# Altium schematic sheet [13] - FPGA POWER.SchDoc("[13] - FPGA POWER")
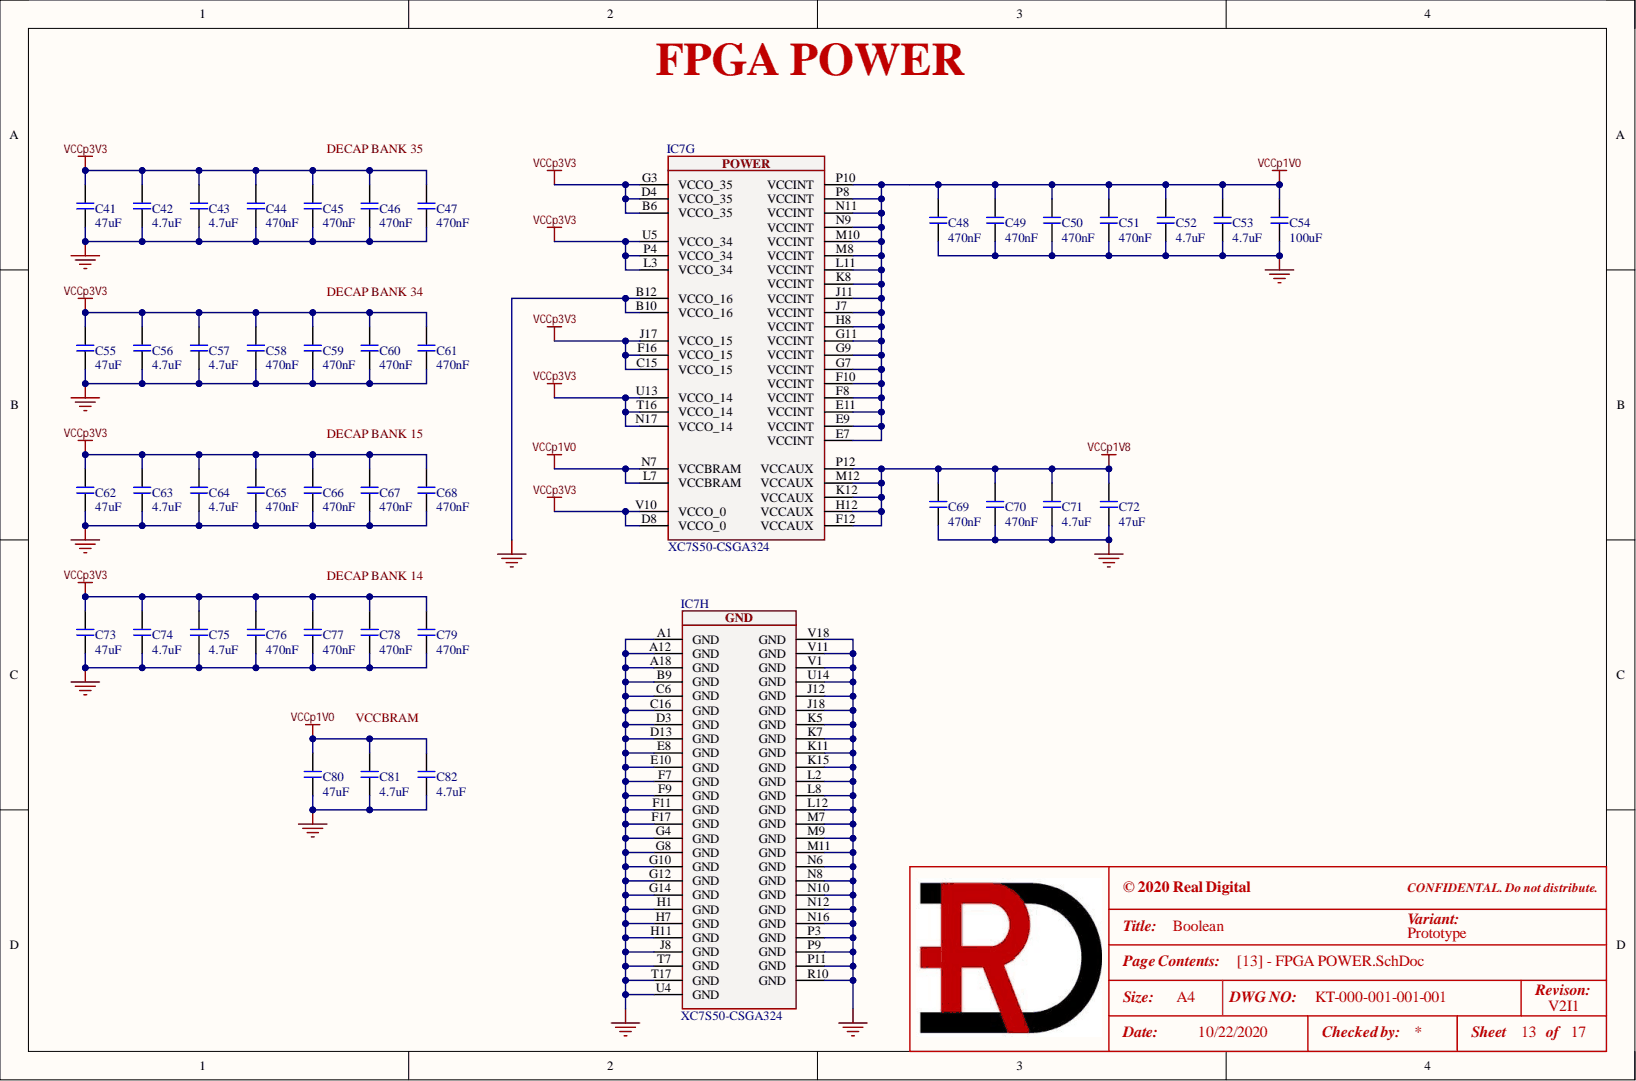
[diagram p0: [13] - FPGA POWER.SchDoc]

## Parts
C41  47uF  [C1210]
C42  4.7uF  [C0805]
C43  4.7uF  [C0805]
C44  470nF  [C0603]
C45  470nF  [C0603]
C46  470nF  [C0603]
C47  470nF  [C0603]
C48  470nF  [C0603]
C49  470nF  [C0603]
C50  470nF  [C0603]
C51  470nF  [C0603]
C52  4.7uF  [C0805]
C53  4.7uF  [C0805]
C54  100uF  [C1210]
C55  47uF  [C1210]
C56  4.7uF  [C0805]
C57  4.7uF  [C0805]
C58  470nF  [C0603]
C59  470nF  [C0603]
C60  470nF  [C0603]
C61  470nF  [C0603]
C62  47uF  [C1210]
C63  4.7uF  [C0805]
C64  4.7uF  [C0805]
C65  470nF  [C0603]
C66  470nF  [C0603]
C67  470nF  [C0603]
C68  470nF  [C0603]
C69  470nF  [C0603]
C70  470nF  [C0603]
C71  4.7uF  [C0805]
C72  47uF  [C1210]
C73  47uF  [C1210]
C74  4.7uF  [C0805]
C75  4.7uF  [C0805]
C76  470nF  [C0603]
C77  470nF  [C0603]
C78  470nF  [C0603]
C79  470nF  [C0603]
C80  47uF  [C1210]
C81  4.7uF  [C0805]
C82  4.7uF  [C0805]
IC7  XC7S50-CSGA324  [CSG324]  (parts G,H)

## Nets
GND: C41.1 C42.1 C43.1 C44.1 C45.1 C46.1 C47.1 C48.1 C49.1 C50.1 C51.1 C52.1 C53.1 C54.1 C55.1 C56.1 C57.1 C58.1 C59.1 C60.1 C61.1 C62.1 C63.1 C64.1 C65.1 C66.1 C67.1 C68.1 C69.1 C70.1 C71.1 C72.1 C73.1 C74.1 C75.1 C76.1 C77.1 C78.1 C79.1 C80.1 C81.1 C82.1 IC7.A1(GND) IC7.A12(GND) IC7.A18(GND) IC7.B9(GND) IC7.B10(VCCO_16) IC7.B12(VCCO_16) IC7.C6(GND) IC7.C16(GND) IC7.D3(GND) IC7.D13(GND) IC7.E8(GND) IC7.E10(GND) IC7.F7(GND) IC7.F9(GND) IC7.F11(GND) IC7.F17(GND) IC7.G4(GND) IC7.G8(GND) IC7.G10(GND) IC7.G12(GND) IC7.G14(GND) IC7.H1(GND) IC7.H7(GND) IC7.H11(GND) IC7.J8(GND) IC7.J12(GND) IC7.J18(GND) IC7.K5(GND) IC7.K7(GND) IC7.K11(GND) IC7.K15(GND) IC7.L2(GND) IC7.L8(GND) IC7.L12(GND) IC7.M7(GND) IC7.M9(GND) IC7.M11(GND) IC7.N6(GND) IC7.N8(GND) IC7.N10(GND) IC7.N12(GND) IC7.N16(GND) IC7.P3(GND) IC7.P9(GND) IC7.P11(GND) IC7.R10(GND) IC7.T7(GND) IC7.T17(GND) IC7.U4(GND) IC7.U14(GND) IC7.V1(GND) IC7.V11(GND) IC7.V18(GND)
VCCp1V0: C48.2 C49.2 C50.2 C51.2 C52.2 C53.2 C54.2 C80.2 C81.2 C82.2 IC7.E7(VCCINT) IC7.E9(VCCINT) IC7.E11(VCCINT) IC7.F8(VCCINT) IC7.F10(VCCINT) IC7.G7(VCCINT) IC7.G9(VCCINT) IC7.G11(VCCINT) IC7.H8(VCCINT) IC7.J7(VCCINT) IC7.J11(VCCINT) IC7.K8(VCCINT) IC7.L7(VCCBRAM) IC7.L11(VCCINT) IC7.M8(VCCINT) IC7.M10(VCCINT) IC7.N7(VCCBRAM) IC7.N9(VCCINT) IC7.N11(VCCINT) IC7.P8(VCCINT) IC7.P10(VCCINT)
VCCp1V8: C69.2 C70.2 C71.2 C72.2 IC7.F12(VCCAUX) IC7.H12(VCCAUX) IC7.K12(VCCAUX) IC7.M12(VCCAUX) IC7.P12(VCCAUX)
VCCp3V3: C41.2 C42.2 C43.2 C44.2 C45.2 C46.2 C47.2 C55.2 C56.2 C57.2 C58.2 C59.2 C60.2 C61.2 C62.2 C63.2 C64.2 C65.2 C66.2 C67.2 C68.2 C73.2 C74.2 C75.2 C76.2 C77.2 C78.2 C79.2 IC7.B6(VCCO_35) IC7.C15(VCCO_15) IC7.D4(VCCO_35) IC7.D8(VCCO_0) IC7.F16(VCCO_15) IC7.G3(VCCO_35) IC7.J17(VCCO_15) IC7.L3(VCCO_34) IC7.N17(VCCO_14) IC7.P4(VCCO_34) IC7.T16(VCCO_14) IC7.U5(VCCO_34) IC7.U13(VCCO_14) IC7.V10(VCCO_0)

## Library data of the parts
C41 C55 C62 C72 C73 C80 = GRM32EC81C476KE15K: CAP CER 47UF 16V X6S 1210; Manufacturer 1: Murata Electronics; Manufacturer 2 Part Number: GRM32EC81C476KE15L; .Checked_PCB: AC; .Creator: RV; LoadStatus: Load; Tolerance: 10%; Category: Capacitors; Component Kind: Standard; Library Name: KT-RealDigital.IntLib; Manufacturer 1 Part Number: GRM32EC81C476KE15K; Manufacturer 2: Murata Electronics; Temperature Coefficient: X6S; Voltage - Rated: 16V; .Checked_SCH: AC
C42 C43 C52 C53 C56 C57 C63 C64 C71 C74 C75 C81 C82 = GRM21BR71C475KE51L: CAP CER 4.7uF 16V X7R 0805; Manufacturer 1: Murata Electronics; .Checked_PCB: AC; .Creator: RV; C P/N: 111-0609; LoadStatus: Load; Tolerance: 10%; Category: Capacitors; Component Kind: Standard; Library Name: KT-RealDigital.IntLib; Manufacturer 1 Part Number: GRM21BR71C475KE51L; Temperature Coefficient: X7R; Voltage - Rated: 16V; .Checked_SCH: AC
C44-C51 C58-C61 C65-C70 C76-C79 = GRM188R71E474KA12D: CAP CER 0.47uF 25V X7R 0603; Manufacturer 1: Murata Electronics; .Checked_PCB: AC; .Creator: RV; C P/N: RD-111-0008; LoadStatus: Load; Tolerance: 10%; Category: Capacitors; Component Kind: Standard; Library Name: KT-RealDigital.IntLib; Manufacturer 1 Part Number: GRM188R71E474KA12D; Temperature Coefficient: X7R; Voltage - Rated: 25V; .Checked_SCH: AC
C54 = GRM32ER61A107ME20L: CAP CER 100UF 10V X5R 1210; Manufacturer 1: Murata Electronics; .Checked_PCB: AC; .Creator: RV; C P/N: RD-111-0004; LoadStatus: Load; Tolerance: 20%; Category: Capacitors; Component Kind: Standard; Library Name: KT-RealDigital.IntLib; Manufacturer 1 Part Number: GRM32ER61A107ME20L; Temperature Coefficient: X5R; Voltage - Rated: 10V; .Checked_SCH: AC
IC7 = XC7S50-CSGA324: FPGA 210 I/O 324CSBGA; Manufacturer 1: Xilinx; .Creator: RV; C P/N: RD-126-0003-CD; LoadStatus: Load; Category: IC; Component Kind: Standard; Library Name: KT-RealDigital.IntLib; Manufacturer 1 Part Number: XC7S50-CSGA324
